# Altium schematic sheet DCDC_Jetson.SchDoc(DCDC_Jetson)
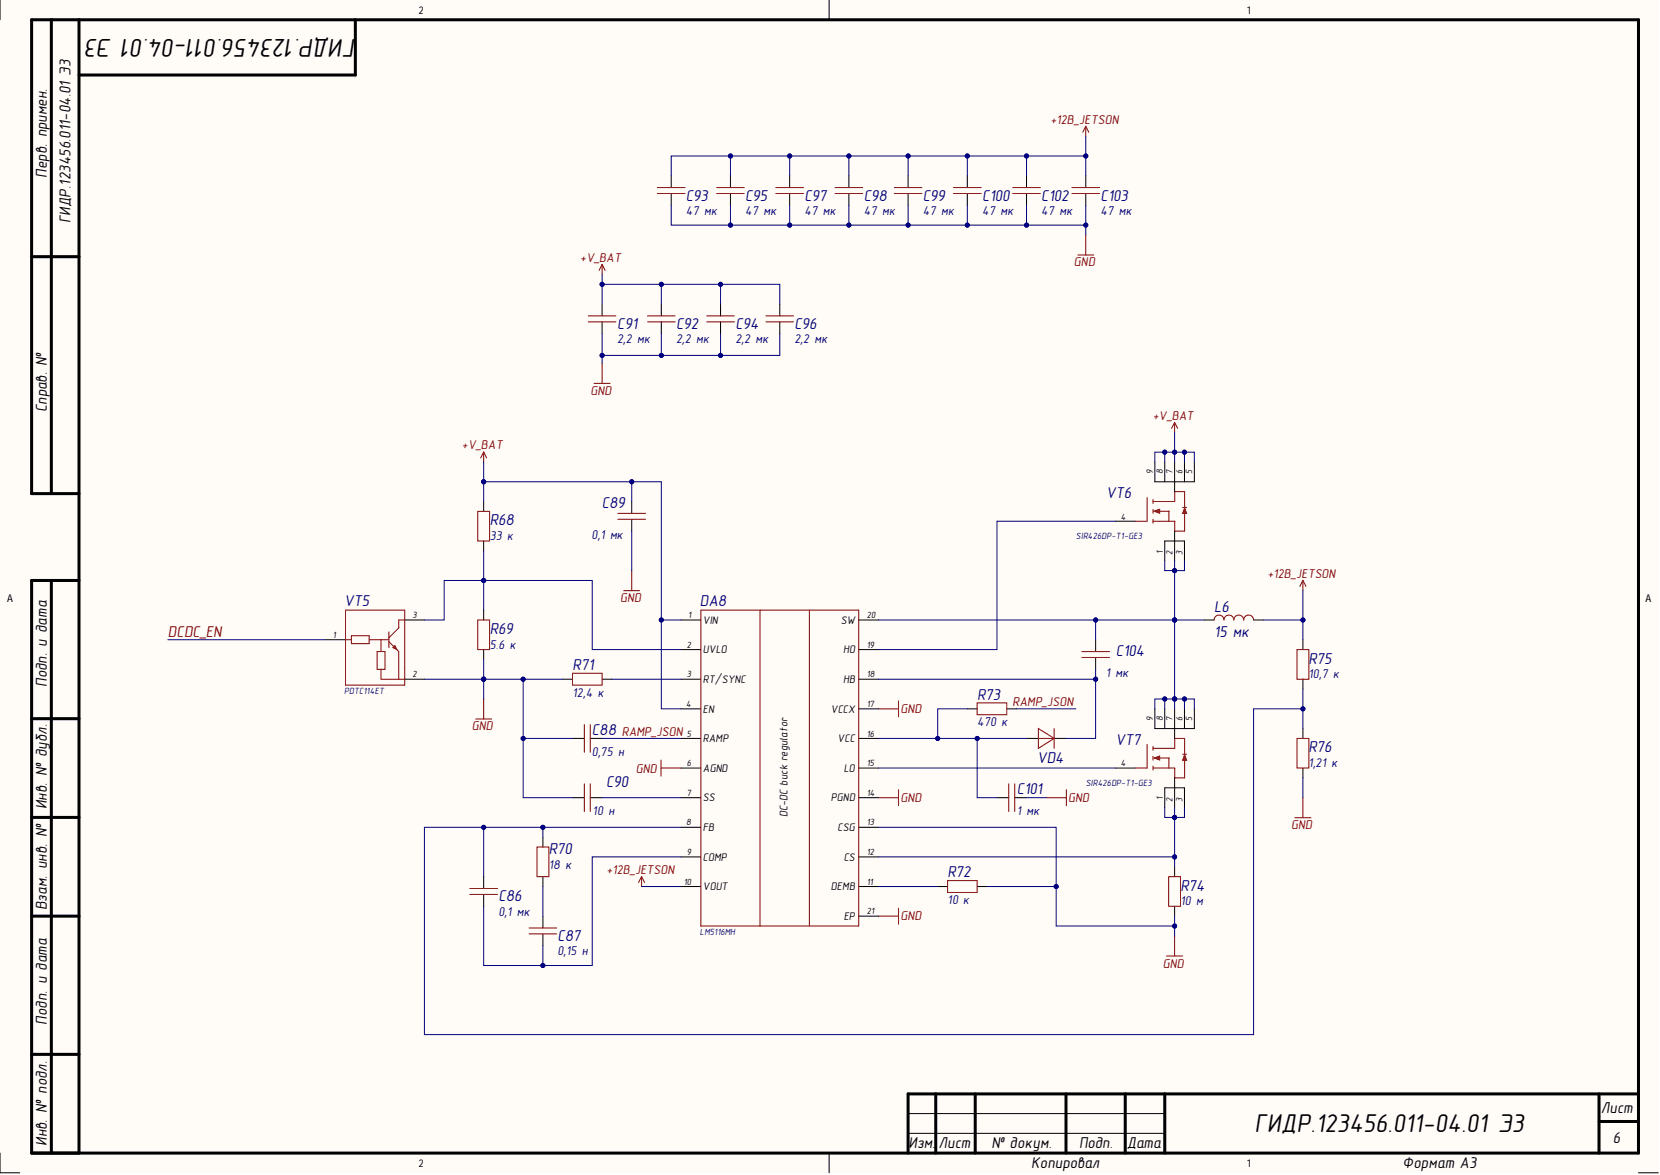
[diagram p0: DCDC_Jetson.SchDoc]

## Parts
C86  value 0,1 мк  [0603C]  (hidden comment: Capacitor)
C87  value 0,15 н  Capacity (nF): 0,15  [0603C]  (hidden comment: Capacitor)
C88  value 0,75 н  Capacity (nF): 0,75  [0603C]  (hidden comment: Capacitor)
C89  value 0,1 мк  Tolerance: 0,1  [0603C]  (hidden comment: Capacitor)
C90  value 10 н  [0603C]  (hidden comment: Capacitor)
C91  Capacitor  [1206C]  (value not drawn)
C92  value 2,2 мк  [1206C]  (hidden comment: Capacitor)
C93  value 47 мк  [1206C]  (hidden comment: GRM31CR61E476ME44L)
C94  value 2,2 мк  [1206C]  (hidden comment: Capacitor)
C95  value 47 мк  [1206C]  (hidden comment: GRM31CR61E476ME44L)
C96  value 2,2 мк  [1206C]  (hidden comment: Capacitor)
C97  value 47 мк  [1206C]  (hidden comment: GRM31CR61E476ME44L)
C98  value 47 мк  [1206C]  (hidden comment: GRM31CR61E476ME44L)
C99  value 47 мк  [1206C]  (hidden comment: GRM31CR61E476ME44L)
C100  value 47 мк  [1206C]  (hidden comment: GRM31CR61E476ME44L)
C101  value 1 мк  [0603C]  (hidden comment: Capacitor)
C102  value 47 мк  [1206C]  (hidden comment: GRM31CR61E476ME44L)
C103  value 47 мк  [1206C]  (hidden comment: GRM31CR61E476ME44L)
C104  value 1 мк  [0603C]  (hidden comment: Capacitor)
DA8  LM5116MH  [HTSSOP-20]
L6  value 15 мк  [IHLP-4040]  (hidden comment: IHLP4040DZER150M11)
R68  value 33 к  [0603R]  (hidden comment: Resistor)
R69  value 5.6 к  [0603R]  (hidden comment: Resistor)
R70  value 18 к  [0603R]  (hidden comment: Resistor)
R71  value 12,4 к  [0603R]  (hidden comment: Resistor)
R72  value 10 к  [0603R]  (hidden comment: Resistor)
R73  value 470 к  [0603R]  (hidden comment: Resistor)
R74  value 10 м  [1210R]  (hidden comment: Resistor)
R75  value 10,7 к  [0603R]  (hidden comment: Resistor)
R76  value 1,21 к  [0603R]  (hidden comment: Resistor)
VD4  S1GFL  [SOD-123F]  (value not drawn)
VT5  PDTC114ET  [SOT23-3]
VT6  SIR426DP-T1-GE3  [PowerPAK_SO-8]
VT7  SIR426DP-T1-GE3  [PowerPAK_SO-8]

## Nets
+12В_JETSON: C93.2 C95.2 C97.2 C98.2 C99.2 C100.2 C102.2 C103.2 DA8.10(VOUT) L6.2 R75.2
+V_BAT: C89.2 C91.2 C92.2 C94.2 C96.2 DA8.1(VIN) DA8.4(EN) R68.2 VT6.5 VT6.6 VT6.7 VT6.8 VT6.9
GND: C88.1 C89.1 C90.1 C91.1 C92.1 C93.1 C94.1 C95.1 C96.1 C97.1 C98.1 C99.1 C100.1 C101.2 C102.1 C103.1 DA8.6(AGND) DA8.13(CSG) DA8.14(PGND) DA8.17(VCCX) DA8.21(EP) R69.1 R71.1 R72.2 R74.1 R76.1 VT5.2
DCDC_EN: VT5.1
RAMP_JSON: C88.2 DA8.5(RAMP) R73.2
NetC86_1: C86.1 C87.1 DA8.9(COMP)
NetC86_2: C86.2 DA8.8(FB) R70.2 R75.1 R76.2
NetC87_2: C87.2 R70.1
NetC90_2: C90.2 DA8.7(SS)
NetC101_1: C101.1 DA8.16(VCC) R73.1 VD4.1
NetC104_1: C104.1 DA8.18(HB) VD4.2
NetC104_2: C104.2 DA8.20(SW) L6.1 VT6.1 VT6.2 VT6.3 VT7.5 VT7.6 VT7.7 VT7.8 VT7.9
NetDA8_2: DA8.2(UVLO) R68.1 R69.2 VT5.3
NetDA8_3: DA8.3(RT/SYNC) R71.2
NetDA8_11: DA8.11(DEMB) R72.1
NetDA8_12: DA8.12(CS) R74.2 VT7.1 VT7.2 VT7.3
NetDA8_15: DA8.15(LO) VT7.4
NetDA8_19: DA8.19(HO) VT6.4

## Library data of the parts
C86 = Capacitor_0,1u_10_50V_X7R_0603C: Конденсатор 0603C ёмкостью 0,1 мк 10%, 50 В, X7R; Capacity (nF): 100; Database Table Name: Capacitors_ceramic$; Value: 0,1 мк; Voltage (V): 50; Component Kind: Standard; Dielectric: X7R; Library Name: Hydrolib.DbLib; Part Number: Capacitor_0,1u_10_50V_X7R_0603C; Tolerance: 10%
C87 = Capacitor_0,15n_10_50V_X7R_0603C: Конденсатор; Database Table Name: Capacitors_ceramic$; Dielectric: X7R; Library Path2: Schematic\Capacitor.SchLib; Tolerance: 0,1; Voltage (V): 50; Component Kind: Standard; Library Name: Hydrolib.DbLib; Part Number: Capacitor_0,15n_10_50V_X7R_0603C
C88 = Capacitor_0,75n_10_50V_X7R_0603C: Конденсатор; Database Table Name: Capacitors_ceramic$; Dielectric: X7R; Library Path2: Schematic\Capacitor.SchLib; Tolerance: 0,1; Voltage (V): 50; Component Kind: Standard; Library Name: Hydrolib.DbLib; Part Number: Capacitor_0,75n_10_50V_X7R_0603C
C89 = Capacitor_0,1u_10_50V_X7R_0603C: Конденсатор; Capacity (nF): 100; Database Table Name: Capacitors_ceramic$; Dielectric: X7R; Library Path2: Schematic\Capacitor.SchLib; Voltage (V): 50; Component Kind: Standard; Library Name: Hydrolib.DbLib; Part Number: Capacitor_0,1u_10_50V_X7R_0603C
C90 = Capacitor_10n_10_50V_X7R_0603C: Конденсатор; Capacity (nF): 10; Database Table Name: Capacitors_ceramic$; Dielectric: X7R; Library Path2: Schematic\Capacitor.SchLib; Tolerance: 0,1; Voltage (V): 50; Component Kind: Standard; Library Name: Hydrolib.DbLib; Part Number: Capacitor_10n_10_50V_X7R_0603C
C91 = Capacitor_2,2u_10_50V_X7R_1206C: Конденсатор 1206C ёмкостью 2,2 мк 10%, 50 В, X7R; Capacity (nF): 2200; Database Table Name: Capacitors_ceramic$; Value: 2,2 мк; Voltage (V): 50; Component Kind: Standard; Dielectric: X7R; Library Name: Hydrolib.DbLib; Part Number: Capacitor_2,2u_10_50V_X7R_1206C; Tolerance: 10%
C92 C94 C96 = Capacitor_2,2u_10_50V_X7R_1206C: Конденсатор 1206C ёмкостью 2,2 мк 10%, 50 В, X7R; Capacity (nF): 2200; Database Table Name: Capacitors_ceramic$; Voltage (V): 50; Component Kind: Standard; Dielectric: X7R; Library Name: Hydrolib.DbLib; Part Number: Capacitor_2,2u_10_50V_X7R_1206C; Tolerance: 10%
C93 = GRM31CR61E476ME44L: Конденсатор 1206C ёмкостью 47 мк 20%, 25 В, X5R; Capacity (nF): 47000; Database Table Name: Capacitors_ceramic$; Value: 47 мк; Voltage (V): 25; Автор: rreali; Component Kind: Standard; Datasheet: https://cdn.promelec.ru/upload/items/2020/02/18/MURATA_MLCC_catalog_C02E_short_.pdf; Dielectric: X5R; Library Name: Hydrolib.DbLib; Part Number: GRM31CR61E476ME44L; Tolerance: 20%
C95 C97-C100 C102 C103 = GRM31CR61E476ME44L: Конденсатор 1206C ёмкостью 47 мк 20%, 25 В, X5R; Capacity (nF): 47000; Database Table Name: Capacitors_ceramic$; Voltage (V): 25; Автор: rreali; Component Kind: Standard; Datasheet: https://cdn.promelec.ru/upload/items/2020/02/18/MURATA_MLCC_catalog_C02E_short_.pdf; Dielectric: X5R; Library Name: Hydrolib.DbLib; Part Number: GRM31CR61E476ME44L; Tolerance: 20%
C101 C104 = Capacitor_1u_10_50V_X7R_0603C: Конденсатор; Capacity (nF): 1000; Database Table Name: Capacitors_ceramic$; Dielectric: X7R; Library Path2: Schematic\Capacitor.SchLib; Tolerance: 0,1; Voltage (V): 50; Component Kind: Standard; Library Name: Hydrolib.DbLib; Part Number: Capacitor_1u_10_50V_X7R_0603C
DA8 = LM5116MH: dc-dc понижающий adj 6-100v; Manufacturer_Part_Number: LM5116MH/NOPB; Database Table Name: Chips$; Component Kind: Standard; Library Name: Hydrolib.DbLib; Part Number: LM5116MH
L6 = IHLP4040DZER150M11: Катушка индуктивности SMD экранированная 15мкГн ±20% 6,25A 45мОм; Current: 6,25 А; Database Table Name: Inductance$; Inductance (μH): 15; Автор: rreali; Component Kind: Standard; Datasheet: cdn.promelec.ru/upload/datasheet/1/f/lp40dz11.pdf; Library Name: Hydrolib.DbLib; Part Number: IHLP4040DZER150M11
R68 = Resistor_33k_1_0603: Резистор номиналом 33 кОм и точностью 1%; Database Table Name: Resistors$; Component Kind: Standard; Library Name: Hydrolib.DbLib; Part Number: Resistor_33k_1_0603; Tolerance: 1%
R69 = Resistor_2.7k_1_0603: Резистор номиналом 2,7 кОм и точностью 1%; Database Table Name: Resistors$; Component Kind: Standard; Library Name: Hydrolib.DbLib; Part Number: Resistor_2.7k_1_0603; Tolerance: 1%
R70 = Resistor_18k_1_0603: Резистор номиналом 18 кОм 1%; Database Table Name: Resistors$; Component Kind: Standard; Library Name: Hydrolib.DbLib; Part Number: Resistor_18k_1_0603; Tolerance: 1%
R71 = Resistor_12.4k_1_0603: Резистор номиналом 12,4 кОм и точностью 1%; Database Table Name: Resistors$; Component Kind: Standard; Library Name: Hydrolib.DbLib; Part Number: Resistor_12.4k_1_0603; Tolerance: 1%
R72 = Resistor_10k_1_0603: Резистор номиналом 10 кОм и точностью 1%; Database Table Name: Resistors$; Component Kind: Standard; Library Name: Hydrolib.DbLib; Part Number: Resistor_10k_1_0603; Tolerance: 1%
R73 = Resistor_470k_1_0603: Резистор номиналом 470 кОм и точностью 1%; Database Table Name: Resistors$; Component Kind: Standard; Library Name: Hydrolib.DbLib; Part Number: Resistor_470k_1_0603; Tolerance: 1%
R74 = RL1210FR-070R01L: Резистор номиналом 10 мОм, точностью 1%, 0.5Вт; Database Table Name: Resistors$; Component Kind: Standard; Library Name: Hydrolib.DbLib; Part Number: RL1210FR-070R01L; Tolerance: 1%
R75 = Resistor_10.7k_1_0603: Резистор номиналом 10,7 кОм 1%; Database Table Name: Resistors$; Component Kind: Standard; Library Name: Hydrolib.DbLib; Part Number: Resistor_10.7k_1_0603; Tolerance: 1%
R76 = Resistor_1.21k_1_0603: Резистор номиналом 1,21 кОм 1%; Database Table Name: Resistors$; Component Kind: Standard; Library Name: Hydrolib.DbLib; Part Number: Resistor_1.21k_1_0603; Tolerance: 1%
VD4 = S1GFL: Диод 400В 1A [SOD-123F]; Current: 1 А; Database Table Name: Rectifier_diodes$; Voltage (V): 400; Автор: rreali; Component Kind: Standard; Datasheet: https://static.chipdip.ru/lib/868/DOC011868843.pdf; Library Name: Hydrolib.DbLib; Part Number: S1GFL
VT5 = PDTC114ET: цифровой npn 50в 100мА; Current (A): 0, 1 А; Database Table Name: Transistors$; Component Kind: Standard; Library Name: Hydrolib.DbLib; Part Number: PDTC114ET; Voltage (V): 50 В
VT6 VT7 = SIR426DP-T1-GE3: Транзистор полевой MOSFET N-канальный 40В 41.7Вт; Database Table Name: Transistors$; Component Kind: Standard; Library Name: Hydrolib.DbLib; Part Number: SIR426DP-T1-GE3; Напряжение С-И/К-Э: 40 В
